# Altium schematic sheet 03-AD9546_EdgeConnections_EEPROM.SchDoc
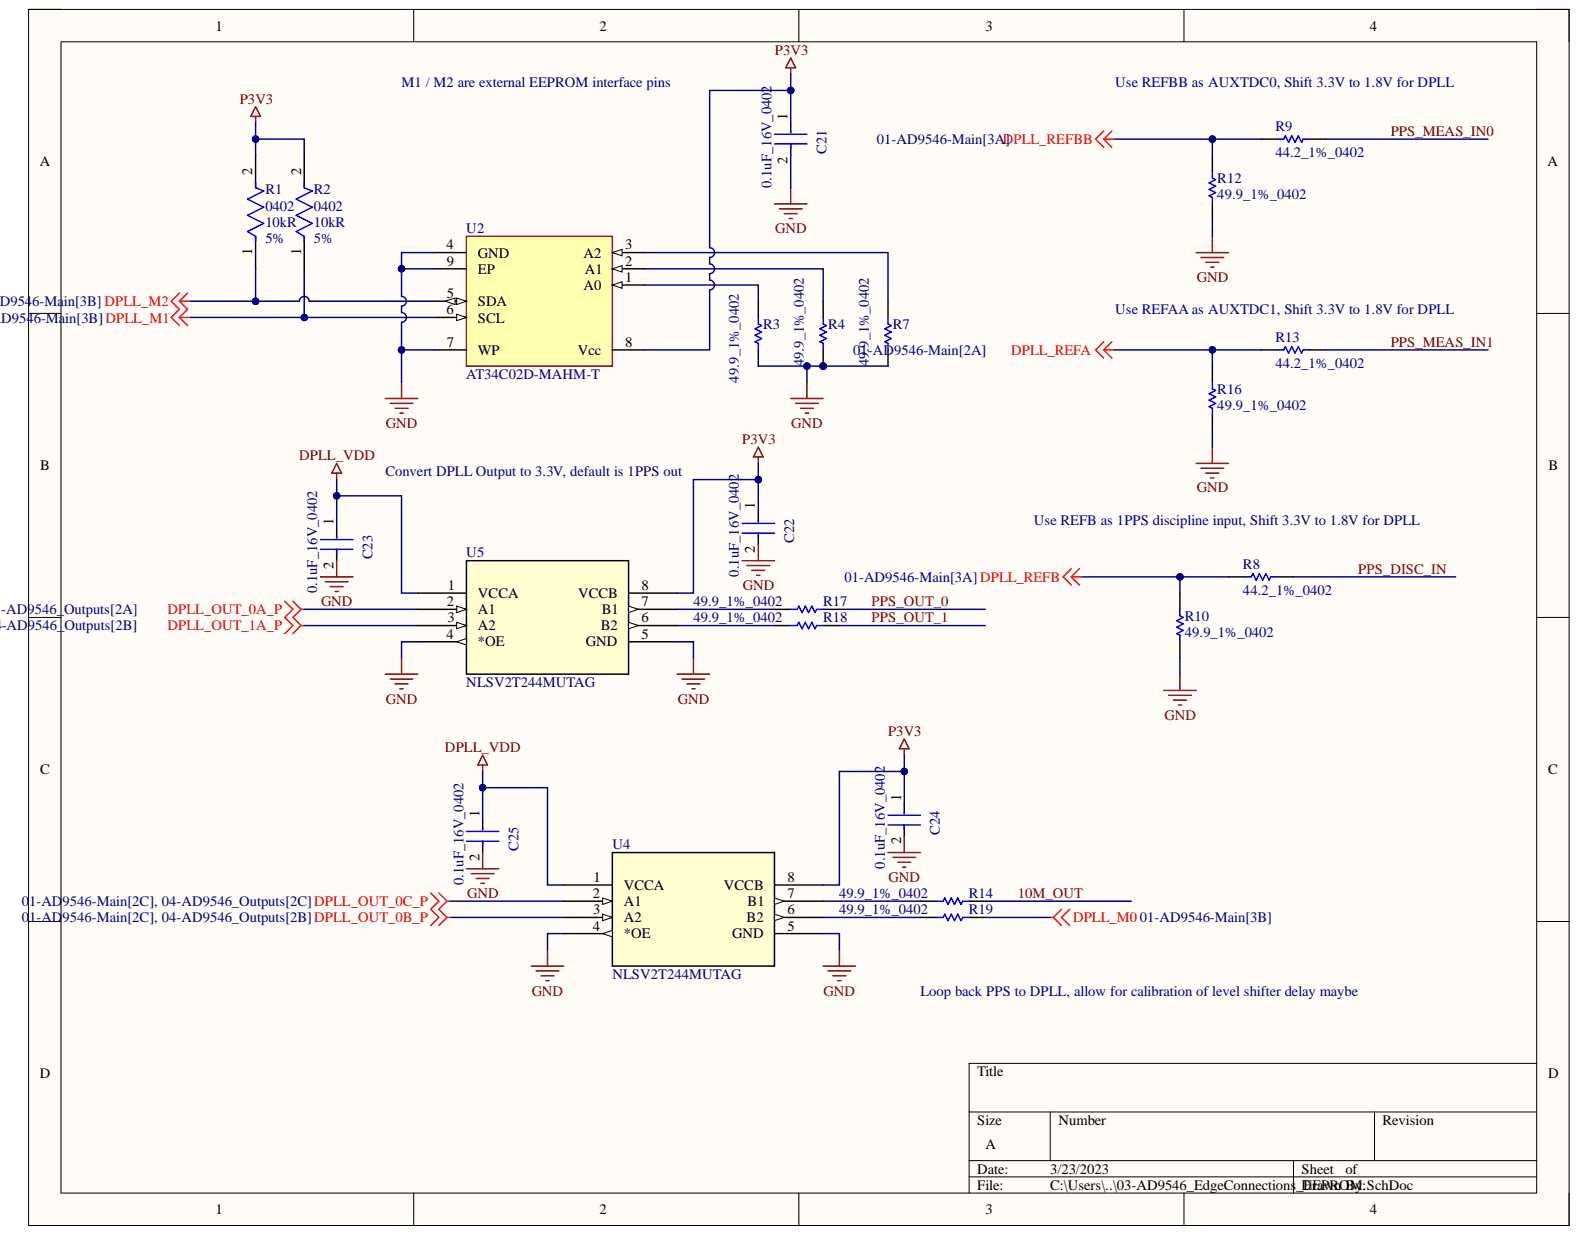
[diagram p0: 03-AD9546_EdgeConnections_EEPROM.SchDoc]

## Parts
C21  0.1uF_16V_0402  [FP-GRM15-MFG]
C22  0.1uF_16V_0402  [FP-GRM15-MFG]
C23  0.1uF_16V_0402  [FP-GRM15-MFG]
C24  0.1uF_16V_0402  [FP-GRM15-MFG]
C25  0.1uF_16V_0402  [FP-GRM15-MFG]
R1  Case Code (Imperial): 0402  Resistance: 10kR  Tolerance: 5%  [FP-CRCW0402-e3-IPC_B]  (hidden comment: CRCW040210K0JNED)
R2  Case Code (Imperial): 0402  Resistance: 10kR  Tolerance: 5%  [FP-CRCW0402-e3-IPC_B]  (hidden comment: CRCW040210K0JNED)
R3  49.9_1%_0402  [RESC1005X40X25NL05T05]
R4  49.9_1%_0402  [RESC1005X40X25NL05T05]
R7  49.9_1%_0402  [RESC1005X40X25NL05T05]
R8  44.2_1%_0402  [RESC1005X40X25LL05T05]
R9  44.2_1%_0402  [RESC1005X40X25LL05T05]
R10  49.9_1%_0402  [RESC1005X40X25NL05T05]
R12  49.9_1%_0402  [RESC1005X40X25NL05T05]
R13  44.2_1%_0402  [RESC1005X40X25LL05T05]
R14  49.9_1%_0402  [RESC1005X40X25NL05T05]
R16  49.9_1%_0402  [RESC1005X40X25NL05T05]
R17  49.9_1%_0402  [RESC1005X40X25NL05T05]
R18  49.9_1%_0402  [RESC1005X40X25NL05T05]
R19  49.9_1%_0402  [RESC1005X40X25NL05T05]
U2  AT34C02D-MAHM-T  [FP-8MA2-IPC_C]
U4  NLSV2T244MUTAG  [UDFN8_1P20X1P80_ONS]
U5  NLSV2T244MUTAG  [UDFN8_1P20X1P80_ONS]

## Nets
DPLL_M0: R19.1
DPLL_M1: R2.1 U2.6(SCL)
DPLL_M2: R1.1 U2.5(SDA)
DPLL_OUT_0A_P: U5.2(A1)
DPLL_OUT_0B_P: U4.3(A2)
DPLL_OUT_0C_P: U4.2(A1)
DPLL_OUT_1A_P: U5.3(A2)
DPLL_REFA: R13.1 R16.2
DPLL_REFB: R8.1 R10.2
DPLL_REFBB: R9.1 R12.2
DPLL_VDD: C23.1 C25.1 U4.1(VCCA) U5.1(VCCA)
GND: C21.2 C22.2 C23.2 C24.2 C25.2 R3.1 R4.1 R7.1 R10.1 R12.1 R16.1 U2.4(GND) U2.7(WP) U2.9(EP) U4.4(*OE) U4.5(GND) U5.4(*OE) U5.5(GND)
P3V3: C21.1 C22.1 C24.1 R1.2 R2.2 U2.8(Vcc) U4.8(VCCB) U5.8(VCCB)
10M_OUT: R14.1
PPS_DISC_IN: R8.2
PPS_MEAS_IN0: R9.2
PPS_MEAS_IN1: R13.2
PPS_OUT_0: R17.1
PPS_OUT_1: R18.1
NetR3_2: R3.2 U2.1(A0)
NetR4_2: R4.2 U2.2(A1)
NetR7_2: R7.2 U2.3(A2)
NetR14_2: R14.2 U4.7(B1)
NetR17_2: R17.2 U5.7(B1)
NetR18_2: R18.2 U5.6(B2)
NetR19_2: R19.2 U4.6(B2)

## Library data of the parts
C21-C25 = CMP-2100-03641-2: CAP CER 0.1UF 16V X7R 0402; Manufacturer: Murata; Manufacturer Part Number: GRM155R71C104KA88D; Capacitance: 0.10μF; Material: Ceramic; Standoff Height: 0mm; Tolerance: 10%; Value: 0.10μF; Voltage Rating: 16Vdc; Category: Cap; Component Kind: Standard; ComponentLink2Description: Datasheet; ComponentLink2URL: https://search.murata.co.jp/Ceramy/image/img/A01X/G101/ENG/GRM155R71H471KA01-01A.pdf; Device Class L1: Passive Components; Device Class L2: Capacitors; Device Class L3: Ceramic Capacitors; Digikey Description: CAP CER 0.1UF 16V X7R 0402; Height: 0.55mm; Ipc Land Pattern Name: CAPC10050X219; Lead Free: yes; Library Name: Altium Content Vault; Mouser Description: 0402 0.1 uF 16V ±10 % Tolerance X7R SMT Multilayer Ceramic Capacitor; Octopart: https://octopart.com/grm155r71c104ka88d-murata-196880; Package: GRM15; RoHS: TRUE; Temperature: -55°C to +125°C; Temperature Characteristic: X7R; Temperature Range High: +125°C; Temperature Range Low: -55°C
R1 R2 = CMP-2002-08136-3: RES Thick Film, 10kΩ, 5%, 0.063W, 200ppm/°C, 0402; Max Power Dissipation: 63mW; Voltage Rating: 50V; Case Code (Metric): 1005; Case/Package: 0402; Component Kind: Standard; Composition: Thick Film; Contact Plating: Tin; Depth: 0.5mm; Features: Automotive AEC-Q200; Height: 0.016inch; Length: 1mm; Library Name: Altium Content Vault; Max Operating Temperature: 155°C; Military Standard: Not; Min Operating Temperature: -55°C; Mount: Surface Mount; Number of Terminations: 2; Package Quantity: 1; Packaging: Tape and Reel; Power Rating: 63mW; Radiation Hardening: No; Temperature Coefficient: 200ppm/°C; Termination: SMD/SMT; Weight: 2.3E-05oz; Width: 0.5mm
R3 R4 R7 R10 R12 R14 R16-R19 = CMP-2002-00969-1: Manufacturer: Panasonic; Part Number: ERJ-2RKF49R9X; Component Kind: Standard; Description: 49.9_1%_0402; Library Name: Altium Content Vault
R8 R9 R13 = CMP-2002-00011-1: Manufacturer: Vishay Dale; Part Number: CRCW040244R2FKED; Component Kind: Standard; Library Name: Altium Content Vault
U2 = CMP-06313-000130-1: IC EEPROM 2KBIT I2C 1MHZ 8UDFN; Component Kind: Standard; Library Name: Altium Content Vault; RoHS Compliant: Yes
U4 U5 = NLSV2T244MUTAG: No Description Available; Mfr_Name: onsemi; Component Kind: Standard; Library Name: NLSV2T244MUTAG.SchLib; Manufacturer_Part_Number: NLSV2T244MUTAG; RefDes: RefDes; Type: DEV; Copyright: Copyright (C) 2023 Ultra Librarian. All rights reserved.
